# Altium schematic sheet 2. SPST Relays.SchDoc("2. SPST Relays")
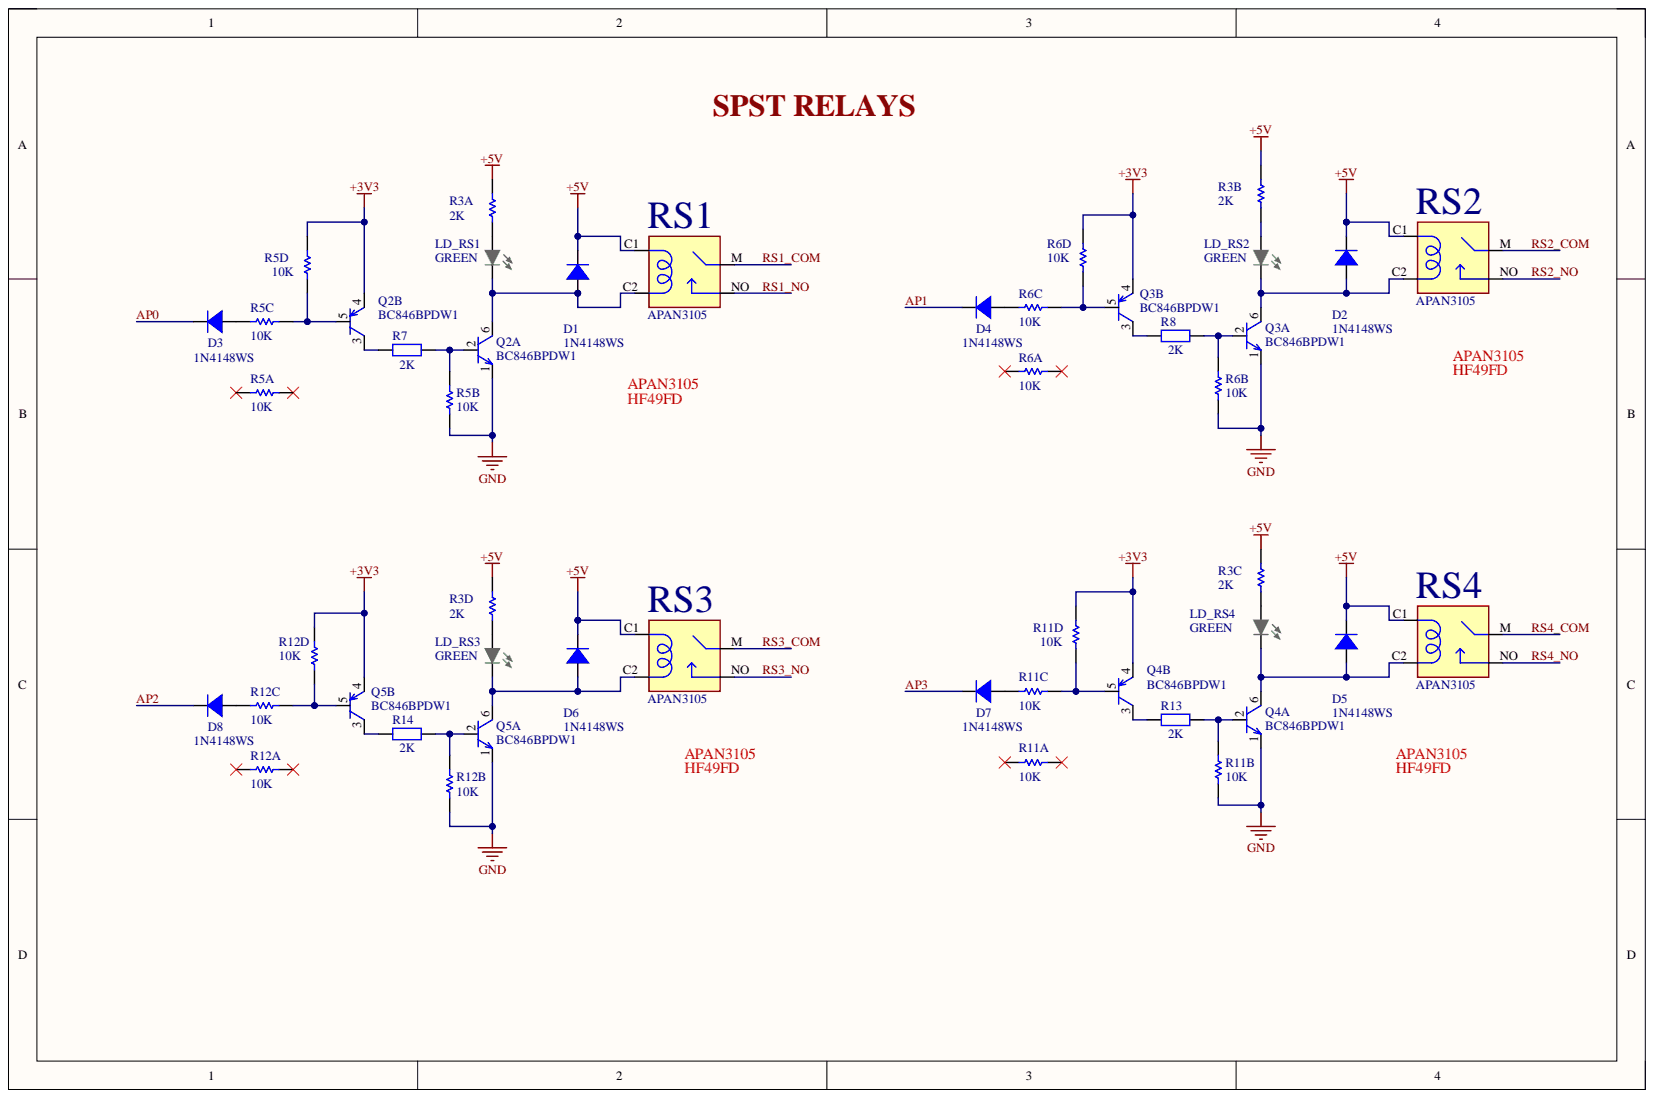
[diagram p0: 2. SPST Relays.SchDoc]

## Parts
D1  1N4148WS  [SOD323FL]
D2  1N4148WS  [SOD323FL]
D3  1N4148WS  [SOD323FL]
D4  1N4148WS  [SOD323FL]
D5  1N4148WS  [SOD323FL]
D6  1N4148WS  [SOD323FL]
D7  1N4148WS  [SOD323FL]
D8  1N4148WS  [SOD323FL]
LD_RS1  GREEN  [LED0603_GREEN]
LD_RS2  GREEN  [LED0603_GREEN]
LD_RS3  GREEN  [LED0603_GREEN]
LD_RS4  GREEN  [LED0603_GREEN]
Q2  BC846BPDW1  [SOT363]  (parts A,B)
Q3  BC846BPDW1  [SOT363]  (parts A,B)
Q4  BC846BPDW1  [SOT363]  (parts A,B)
Q5  BC846BPDW1  [SOT363]  (parts A,B)
R3  2K  [CAC-50P200X100-8M_10K]  (parts A,B,C,D)
R5  10K  [CAC-50P200X100-8M_10K]  (parts A,B,C,D)
R6  10K  [CAC-50P200X100-8M_10K]  (parts A,B,C,D)
R7  2K  [R0402]
R8  2K  [R0402]
R11  10K  [CAC-50P200X100-8M_10K]  (parts A,B,C,D)
R12  10K  [CAC-50P200X100-8M_10K]  (parts A,B,C,D)
R13  2K  [R0402]
R14  2K  [R0402]
RS1  APAN3105  [RELAY_APAN3105]
RS2  APAN3105  [RELAY_APAN3105]
RS3  APAN3105  [RELAY_APAN3105]
RS4  APAN3105  [RELAY_APAN3105]

## Nets
+3V3: Q2.4 Q3.4 Q4.4 Q5.4 R5.4 R6.4 R11.4 R12.4
+5V: D1.1 D2.1 D5.1 D6.1 R3.5 R3.6 R3.7 R3.8 RS1.C1 RS2.C1 RS3.C1 RS4.C1
GND: Q2.1 Q3.1 Q4.1 Q5.1 R5.2 R6.2 R11.2 R12.2
AP0: D3.1
AP1: D4.1
AP2: D8.1
AP3: D7.1
RS1_COM: RS1.M
RS1_NO: RS1.NO
RS2_COM: RS2.M
RS2_NO: RS2.NO
RS3_COM: RS3.M
RS3_NO: RS3.NO
RS4_COM: RS4.M
RS4_NO: RS4.NO
NetD1_2: D1.2 LD_RS1.K Q2.6 RS1.C2
NetD2_2: D2.2 LD_RS2.K Q3.6 RS2.C2
NetD3_2: D3.2 R5.3
NetD4_2: D4.2 R6.3
NetD5_2: D5.2 LD_RS4.K Q4.6 RS4.C2
NetD6_2: D6.2 LD_RS3.K Q5.6 RS3.C2
NetD7_2: D7.2 R11.3
NetD8_2: D8.2 R12.3
NetLD_RS1_A: LD_RS1.A R3.1
NetLD_RS2_A: LD_RS2.A R3.2
NetLD_RS3_A: LD_RS3.A R3.4
NetLD_RS4_A: LD_RS4.A R3.3
NetQ2_2: Q2.2 R5.7 R7.1
NetQ2_3: Q2.3 R7.2
NetQ2_5: Q2.5 R5.5 R5.6
NetQ3_2: Q3.2 R6.7 R8.1
NetQ3_3: Q3.3 R8.2
NetQ3_5: Q3.5 R6.5 R6.6
NetQ4_2: Q4.2 R11.7 R13.1
NetQ4_3: Q4.3 R13.2
NetQ4_5: Q4.5 R11.5 R11.6
NetQ5_2: Q5.2 R12.7 R14.1
NetQ5_3: Q5.3 R14.2
NetQ5_5: Q5.5 R12.5 R12.6

Not connected: R5 1 8; R6 1 8; R11 1 8; R12 1 8

## Library data of the parts
D1-D8 = DIODE_1N4148WS: DIODE GEN PURP 75V 150MA SOD323F; Manufacturer: ON Semiconductor / Fairchild; Element Configuration: Single; Forward Voltage: 1V; Max Forward Surge Current (Ifsm): 2A; Max Reverse Current: 5uA; Power Dissipation: 200mW; Recovery Time: 4ns; Average Rectified Current: 150mA; Category: Rectifier Diodes; Component Kind: Standard; ComponentLink1Description: https://datasheet.ciiva.com/25968/1n914bws-25968945.pdf?src-supplier=Avnet+Europe; ComponentLink1URL: https://datasheet.ciiva.com/25968/1n914bws-25968945.pdf?src-supplier=Avnet+Europe; ComponentLink2Description: https://datasheet.ciiva.com/30479/0900766b810e1cd3-30479206.pdf?src-supplier=RSComponents; ComponentLink2URL: https://datasheet.ciiva.com/30479/0900766b810e1cd3-30479206.pdf?src-supplier=RSComponents; ComponentLink3Description: https://datasheet.ciiva.com/8300/1n914bws-8300360.pdf?src-supplier=Avnet; ComponentLink3URL: https://datasheet.ciiva.com/8300/1n914bws-8300360.pdf?src-supplier=Avnet; ComponentLink4Description: https://datasheet.ciiva.com/15208/getdatasheetpartid-127819-15208358.pdf?src-supplier=Verical; ComponentLink4URL: https://datasheet.ciiva.com/15208/getdatasheetpartid-127819-15208358.pdf?src-supplier=Verical; ComponentLink5Description: https://datasheet.ciiva.com/910/1n4148ws-910381.pdf?src-supplier=Digi-Key; ComponentLink5URL: https://datasheet.ciiva.com/910/1n4148ws-910381.pdf?src-supplier=Digi-Key; ComponentLink6Description: https://datasheet.ciiva.com/910/1n4148ws-910382.pdf?src-supplier=Digi-Key; ComponentLink6URL: https://datasheet.ciiva.com/910/1n4148ws-910382.pdf?src-supplier=Digi-Key; ComponentLink7Description: https://datasheet.ciiva.com/910/1n4148ws-910380.pdf?src-supplier=Digi-Key; ComponentLink7URL: https://datasheet.ciiva.com/910/1n4148ws-910380.pdf?src-supplier=Digi-Key; Contact Plating: Tin,Matte; Forward Current: 150mA; Height: 1mm; Lead Free: Lead Free; Length: 1.8mm; Manufacturer Part Number: 1N4148WS; Max Operating Temperature: 150°C; Max Repetitive Reverse Voltage (Vrrm): 75V; Max Reverse Voltage (DC): 75V; Min Operating Temperature: -55°C; Mount: Surface Mount; Number of Pins: 2; Package Quantity: 1; Packaging: Tape and Reel; Pricing 1: 1=0.21000, 10=0.19200, 100=0.10460, 500=0.06436, 1000=0.04388 (USD); Radiation Hardening: No; REACH SVHC: No SVHC; Reverse Recovery Time: 4ns; Reverse Voltage: 75V; RoHS: Yes; RoHS Compliant: Yes; Series: -; Stock 1: 680869; Supplier 1: Digi-Key; Supplier Part Number 1: 1N4148WSFSCT-ND; Weight: 0.0276g; Width: 1.35mm; Library Name: Bokra.SCHLIB
LD_RS1-LD_RS4 = LED0603_GREEN: LED GREEN CLEAR SMD; Manufacturer: XINGLIGHT; Manufacturer Part Number: XL-1608SYGC-06; Dominant Wavelength: 571nm; Forward Current: 30mA; Forward Voltage: 2V; Lens Color: Colorless; Lens Style: Rectangular,Colorless; Luminous Intensity: 35mcd; Max Power Dissipation: 75mW; Number of Elements: 1; Reverse Voltage: 5V; Test Current: 20mA; Viewing Angle: 130°; Wavelength: 574nm; Case Code (Imperial): 0603; Case Code (Metric): 1608; Case/Package: 0603; Category: LEDs; Color: Green; Component Kind: Standard; ComponentLink1Description: https://datasheet.ciiva.com/2109/ltst-c191kgkt-2109973.pdf?src-supplier=Digi-Key; ComponentLink1URL: https://datasheet.ciiva.com/2109/ltst-c191kgkt-2109973.pdf?src-supplier=Digi-Key; Depth: 0.8mm; Height: 0.55mm; Illumination Color: Green; Lead Free: Lead Free; Length: 1.6mm; Lens Transparency: Clear; Library Name: Schematic_Lib.SchLib; Max Operating Temperature: 85°C; Min Operating Temperature: -55°C; Mount: Surface Mount; Number of LEDs: 1; Number of Pins: 2; Package Quantity: 1; Packaging: Tape and Reel; Power Dissipation: 75mW; Power Rating: 75mW; Pricing 1: 1=0.26000, 10=0.14100, 100=0.06560, 500=0.06060, 1000=0.04747, 2000=0.04646 (USD); Radiation Hardening: No; RoHS: Yes; RoHS Compliant: Yes; Stock 1: 910352; Supplier 1: LCSC; Supplier Part Number 1: C965805; Width: 0.8mm
Q2-Q5 = BC846BPDW1_2parts: Dual PNP/NPN transistors SOT363; Component Kind: Standard; PartNumber1: BC846BPDW1; PartNumber2: BC846BPN; Value: BC846BPDW1; Library Name: Bokra.SCHLIB
R3 = EXB-28V202JX: RES ARRAY 4 RES 2K OHM 0804; Component Kind: Standard; LCSC #: C2654103; Value: EXB-28V202JX; Library Name: Bokra.SCHLIB
R5 R6 R11 R12 = EXB-28V103JX: RES ARRAY 4 RES 10K OHM 0804; Component Kind: Standard; LCSC #: C178757; Value: EXB-28V103JX; Library Name: Bokra.SCHLIB
R7 R8 R13 R14 = 0402WGF2001TCE: -55℃~+155℃ 2kΩ 50V 62.5mW Thick Film Resistor ±1% ±100ppm/℃ 0402 Chip Resistor - Surface Mount ROHS; Component Kind: Standard; LCSC #: C4109; MPN: 0402WGF2001TCE; Value: 2K; Library Name: Bokra.SCHLIB
RS1-RS4 = APAN3105: PA-N Relay 5V 1FormA, 5A@250VAC, 30VDC | Panasonic Electronic Components APAN3105; Check_prices: https://www.snapeda.com/parts/APAN3105/Panasonic+Electric+Works/view-part/?ref=eda; Component Kind: Standard; MF: Panasonic; MP: APAN3105; Package: None; SnapEDA_Link: https://www.snapeda.com/parts/APAN3105/Panasonic+Electric+Works/view-part/?ref=snap; Library Name: Bokra.SCHLIB
